# Altium schematic sheet Voiced Sample Box V9.00.schdoc
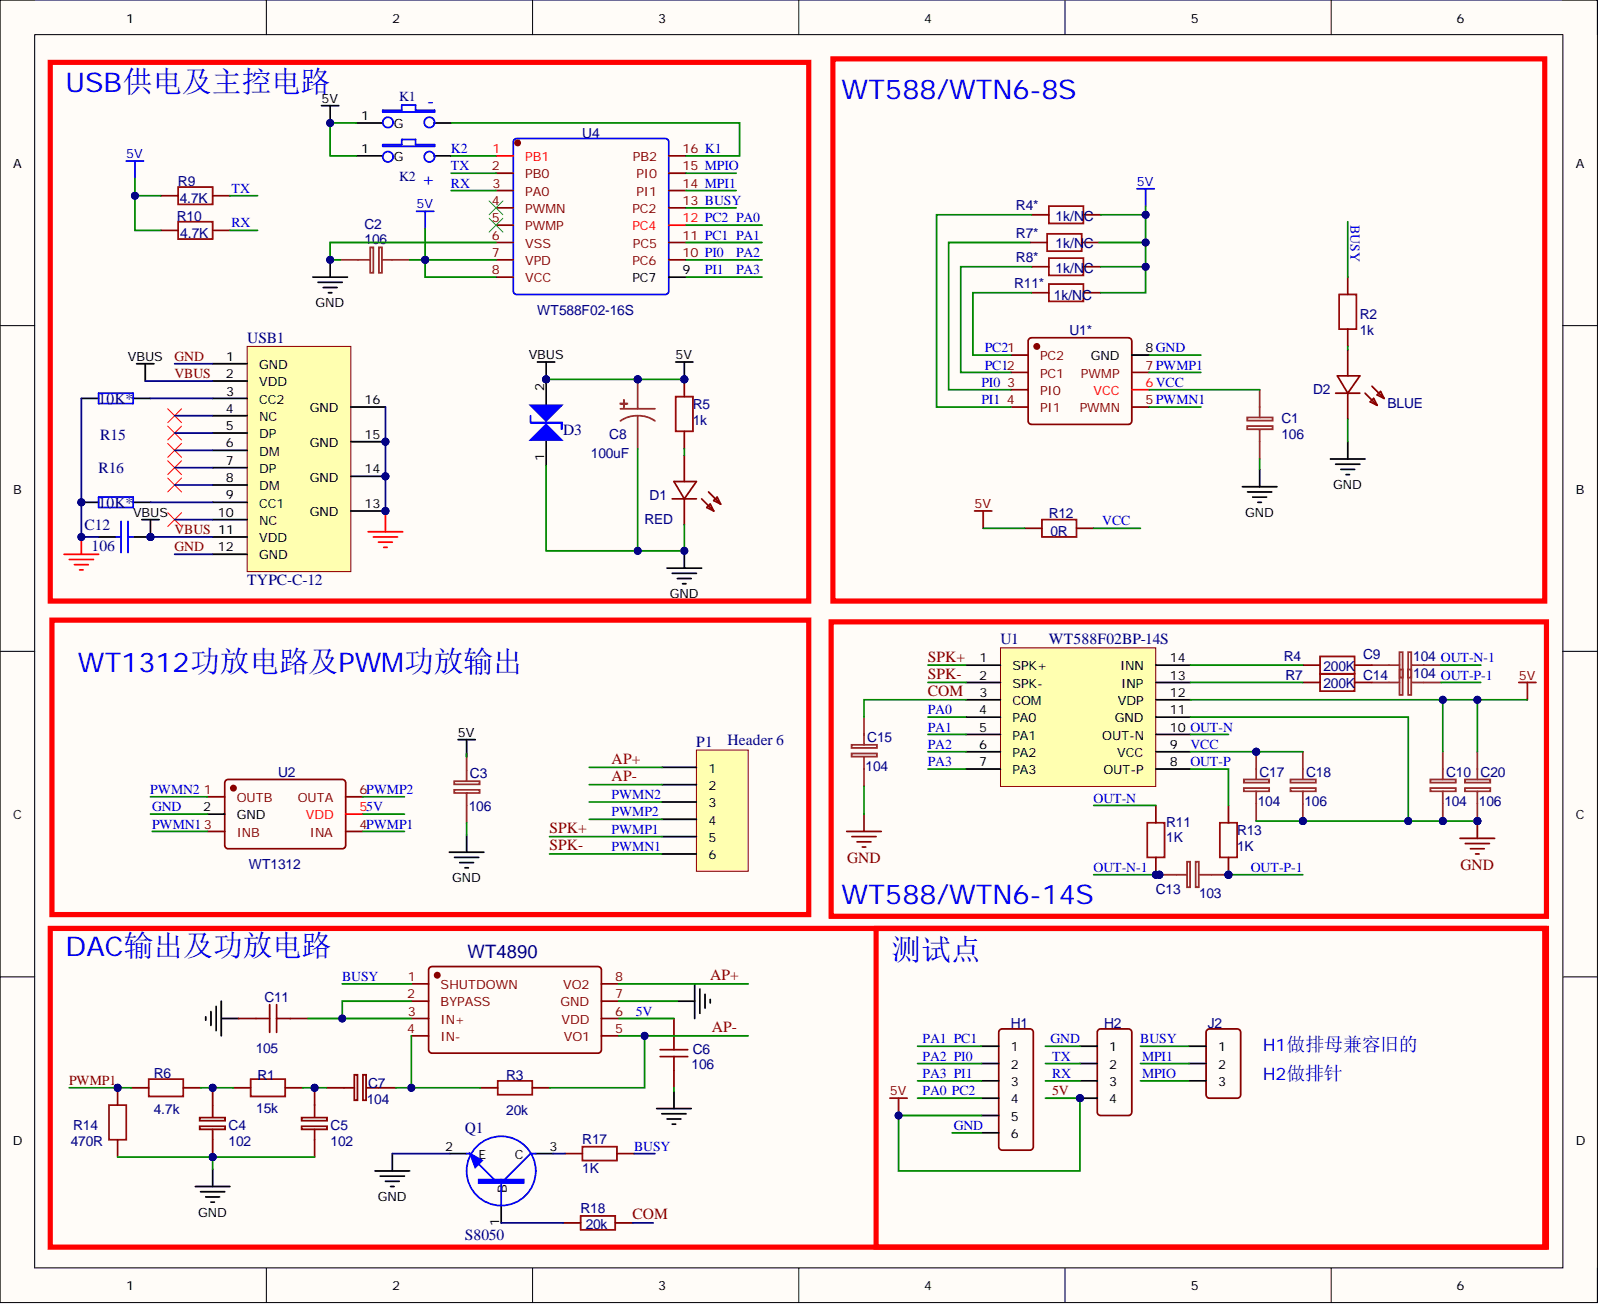
[diagram p0: Voiced Sample Box V9.00.schdoc]

## Parts
C1  106  [C-0603]
C2  106  [C-0603]
C3  106  [C-0603]
C4  102  [C-0603]
C5  102  [C-0603]
C6  106  [C-0603]
C7  104  [C-0603]
C8  100uF  [CAP-SMD-6.3*5.4]
C9  104  [C-0603]
C10  104  [C-0603]
C11  105  [C-0603]
C12  106  [C-0603]
C13  103  [C-0603]
C14  104  [C-0603]
C15  104  [C-0603]
C17  104  [C-0603]
C18  106  [C-0603]
C20  106  [C-0603]
D1  RED  [LED-0603]
D2  BLUE  [LED-0603]
D3  DIODE ZENER2  [r-0603]  (value not drawn)
H1  HDR-F-2.54_1x6  [SIP-6]  (value not drawn)
H2  HDR-F-2.54_1x4  [HDR-F-2.54_1X4]  (value not drawn)
J2  2.54_1x3  [sip-3]  (value not drawn)
K1  K1  [KEY-6*3*2.5]
K2  SW-PB  [KEY-6*3*2.5]  (value not drawn)
P1  Header 6  [HDR1X6]
Q1  S8050  [SOT-23]
R1  15k  [R-0603]
R2  1k  [R-0603]
R3  20k  [R-0603]
R4  200K  [R0603]
R4*  1k/NC  [R-0603]
R5  1k  [R-0603]
R6  4.7k  [R-0603]
R7  200K  [R0603]
R7*  1k/NC  [R-0603]
R8*  1k/NC  [R-0603]
R9  4.7K  [R-0603]
R10  4.7K  [R-0603]
R11  1K  [R0603]
R11*  1k/NC  [R-0603]
R12  0R  [R0603]
R13  1K  [R0603]
R14  470R  [R-0603]
R15  10K*  [R-0603]
R16  10K*  [R-0603]
R17  1K  [R-0603]
R18  20k  [R-0603]
U1  WT588F02BP-14S  [SOP-14-1.27-6]
U1*  WT588F-8S  [SOP-8]  (value not drawn)
U2  WT1312  [SOT23-6]
U4  WT588F02-16S  [SOP16_150MIL]
U8  WT4890  [MSOP-8]
USB1  TYPC-C-12  [TYPE-C-12-1]

## Nets
5V: C2.1 C3.1 C6.1 C8.1 C10.1 C12.1 C20.1 D3.2 H1.5 H2.4 K1.1 K2.1 R4*.1 R5.2 R7*.1 R8*.1 R9.2 R10.2 R11*.1 R12.2 U1.12(VDP) U2.5(VDD) U4.7(VPD) U4.8(VCC) U8.6(VDD) USB1.2(VDD) USB1.11(VDD)
AP+: P1.1 U8.8(VO2)
AP-: P1.2 R3.2 U8.5(VO1)
BUSY: J2.1 R2.2 R17.1 U4.13(PC2) U8.1(SHUTDOWN)
COM: C15.1 R18.2 U1.3(COM)
GND: C1.2 C2.2 C3.2 C4.1 C5.1 C6.2 C8.2 C10.2 C11.2 C12.2 C15.2 C17.2 C18.2 C20.2 D1.2 D2.2 D3.1 H1.6 H2.1 Q1.2 R14.1 R15.2 R16.2 U1.11(GND) U1*.8(GND) U2.2(GND) U4.6(VSS) U8.7(GND) USB1.1(GND) USB1.12(GND) USB1.13(GND) USB1.14(GND) USB1.15(GND) USB1.16(GND)
K1: K1.2 U4.16(PB2)
K2: K2.2 U4.1(PB1)
MPI1: J2.2 U4.14(PI1)
MPIO: J2.3 U4.15(PI0)
OUT-N: R11.1 U1.10(OUT-N)
OUT-N-1: C9.2 C13.1 R11.2
OUT-P: R13.1 U1.8(OUT-P)
OUT-P-1: C13.2 C14.2 R13.2
PA0: H1.4 R11*.2 U1.4(PA0) U1*.1(PC2) U4.12(PC4)
PA1: H1.1 R8*.2 U1.5(PA1) U1*.2(PC1) U4.11(PC5)
PA2: H1.2 R7*.2 U1.6(PA2) U1*.3(PI0) U4.10(PC6)
PA3: H1.3 R4*.2 U1.7(PA3) U1*.4(PI1) U4.9(PC7)
PWMN1: P1.6 U1.2(SPK-) U1*.5(PWMN) U2.3(INB)
PWMN2: P1.3 U2.1(OUTB)
PWMP1: P1.5 R6.1 R14.2 U1.1(SPK+) U1*.7(PWMP) U2.4(INA)
PWMP2: P1.4 U2.6(OUTA)
RX: H2.3 R10.1 U4.3(PA0)
TX: H2.2 R9.1 U4.2(PB0)
VCC: C1.1 C17.1 C18.1 R12.1 U1.9(VCC) U1*.6(VCC)
NetC4_2: C4.2 R1.1 R6.2
NetC5_2: C5.2 C7.1 R1.2
NetC7_2: C7.2 R3.1 U8.4(IN-)
NetC9_1: C9.1 R4.2
NetC11_1: C11.1 U8.2(BYPASS) U8.3(IN+)
NetC14_1: C14.1 R7.2
NetD1_1: D1.1 R5.1
NetD2_1: D2.1 R2.1
NetQ1_1: Q1.1 R18.1
NetQ1_3: Q1.3 R17.2
NetR4_1: R4.1 U1.14(INN)
NetR7_1: R7.1 U1.13(INP)
NetR15_1: R15.1 USB1.3(CC2)
NetR16_1: R16.1 USB1.9(CC1)

Not connected: U4 4(PWMN) 5(PWMP); USB1 4(NC) 5(DP) 6(DM) 7(DP) 8(DM) 10(NC)

## Library data of the parts
C1 C2 C9 C10 C13-C15 C17 C18 C20 = 106: 106 0603 10V 20%; Inventory Code: 01.002.001.0186; nameAlias: Value(F); Produck Name: 贴片普通电容; BOM_Manufacturer Part: ?; Component Kind: Standard; Footprint Path: .\Footprint_Lib_Custom\SMD贴片库.lib; Footprint Ref: C-0603; Library Path: .\Schematic Library\02 Capacitor.SCHLIB; Library Ref: CN-CAP; spicePre: C; spiceSymbolName: Cap_RAD-0.1_EU
C3 C7 = 104: 104 0603 50V X7R 三星牌子; Inventory Code: 01.002.001.0150; nameAlias: Value(F); Produck Name: 贴片普通电容; BOM_Manufacturer Part: ?; Component Kind: Standard; Footprint Path: .\Footprint_Lib_Custom\SMD贴片库.lib; Footprint Ref: C-0603; Library Path: .\Schematic Library\02 Capacitor.SCHLIB; Library Ref: CN-CAP; spicePre: C; spiceSymbolName: Cap_RAD-0.1_EU
C4 C5 = 102: 102 0603 50V 10% X7R; Inventory Code: 01.002.001.0004; nameAlias: Value(F); Produck Name: 贴片普通电容; BOM_Manufacturer Part: ?; Component Kind: Standard; Footprint Path: .\Footprint_Lib_Custom\SMD贴片库.lib; Footprint Ref: C-0603; Library Path: .\Schematic Library\02 Capacitor.SCHLIB; Library Ref: CN-CAP; spicePre: C; spiceSymbolName: Cap_RAD-0.1_EU
C6 C11 = 105: 105 0603 10V -20+80% Y5V; Inventory Code: 01.002.001.0007; nameAlias: Value(F); Produck Name: 贴片普通电容; BOM_Manufacturer Part: ?; Component Kind: Standard; Footprint Path: .\Footprint_Lib_Custom\SMD贴片库.lib; Footprint Ref: C-0603; Library Path: .\Schematic Library\02 Capacitor.SCHLIB; Library Ref: CN-CAP; spicePre: C; spiceSymbolName: Cap_RAD-0.1_US
C8 = 47uF/16V: BOM_Manufacturer: SUN Elec Industries; BOM_Manufacturer Part: 25CE22AX; Contributor: LCSC; nameAlias: Capacitance; BOM_Supplier: LCSC; BOM_Supplier Part: C242039; Component Kind: Standard; spicePre: C; spiceSymbolName: 25CE22AX copy
C12 = CAP: Capacitor; Component Kind: Standard
D1 = RED: 红灯 0603; BOM_Manufacturer: EVERLIGHT; BOM_Manufacturer Part: 204-10SURD/S530-A3; Inventory Code: 01.014.0008; Produck Name: 贴片LED红灯; BOM_Supplier: LCSC; BOM_Supplier Part: C99772; Component Kind: Standard; Footprint Path: .\Footprint_Lib_Custom\二三极管.PcbLib; Footprint Ref: LED-0603; Library Ref: CN-LED; spicePre: L; Library Path: .\Schematic_Lib_Custom\二三极管.SchLib
D2 = BLUE: BOM_Manufacturer: EVERLIGHT; BOM_Manufacturer Part: 204-10SURD/S530-A3; BOM_Supplier: LCSC; BOM_Supplier Part: C99772; Component Kind: Standard; spicePre: L
D3 = DIODE ZENER2: 双向稳压二极管 TVS管; Component Kind: Standard; Library Name: Diode.SchLib
H1 = HDR-F-2.54_1x6: Contributor: LCEDA_Lib; BOM_Supplier: LCSC; BOM_Supplier Part: C40877; Component Kind: Standard; spicePre: H; spiceSymbolName: HDR-F-2.54_1x6
H2 = HDR-F-2.54_1x4: Contributor: LCEDA_Lib; BOM_Supplier: LCSC; BOM_Supplier Part: C225501; Component Kind: Standard; spicePre: H; spiceSymbolName: HDR-F-2.54_1x4
J2 = 2.54_1x3: BOM_Manufacturer: BOOMELE; BOM_Manufacturer Part: Header2.54mm 1*3P; BOM_JLCPCB Part Class: Extended Part; BOM_Supplier: LCSC; BOM_Supplier Part: C49257; Component Kind: Standard; spicePre: H; spiceSymbolName: Header-Male-2.54_1x3
P1 = Header 6: Header, 6-Pin; Component Kind: Standard; LatestRevisionDate: 17-Jul-2002; LatestRevisionNote: Re-released for DXP Platform.; Library Name: Miscellaneous Connectors.IntLib; Signal Integrity: Connector; Publisher: Altium Limited
Q1 = NPN-1: NPN Transistor; Component Kind: Standard; Library Name: Diode.SchLib
R1 = 15k: 15K 0603 1%; Contributor: LCEDA_Lib; Inventory Code: 01.001.001.0022; nameAlias: Value(Ω); Produck Name: 贴片电阻; Component Kind: Standard; Footprint Path: .\Footprint_Lib_Custom\SMD贴片库.lib; Footprint Ref: R-0603; Library Path: .\Schematic Library\01 Resistor.SCHLIB; Library Ref: CN-RES2; spicePre: R; spiceSymbolName: R_0603_EU
R2 R5 = 1k: 1K 0603 1%; Inventory Code: 01.001.001.0335; nameAlias: Value(Ω); Produck Name: 贴片电阻; BOM_Manufacturer Part: ?; Component Kind: Standard; Footprint Path: .\Footprint_Lib_Custom\SMD贴片库.lib; Footprint Ref: R-0603; Library Path: .\Schematic Library\01 Resistor.SCHLIB; Library Ref: CN-RES2; spicePre: R; spiceSymbolName: Res_0603(1/10W)_EU
R3 R17 R18 = 20k: 20K 0603 1%; Inventory Code: 01.001.001.0346; nameAlias: Value(Ω); Produck Name: 贴片电阻; BOM_Manufacturer Part: ?; Component Kind: Standard; Footprint Path: .\Footprint_Lib_Custom\SMD贴片库.lib; Footprint Ref: R-0603; Library Path: .\Schematic Library\01 Resistor.SCHLIB; Library Ref: CN-RES2; spicePre: R; spiceSymbolName: Res_AXIAL-0.3(1/8W)_EU
R4 R7 R11-R13 = 0R: nameAlias: Value(Ω); BOM_Manufacturer Part: ?; Component Kind: Standard; spicePre: R; spiceSymbolName: Res_0603(1/10W)_EU
R4* R7* R8* R11* = 1k: nameAlias: Value(Ω); BOM_Manufacturer Part: ?; Component Kind: Standard; spicePre: R; spiceSymbolName: Res_0603(1/10W)_EU
R6 = 4.7k: 4.7K 0603 5%; Contributor: LCEDA_Lib; Inventory Code: 01.001.001.0068; nameAlias: Value(Ω); Produck Name: 贴片电阻; Component Kind: Standard; Footprint Path: .\Footprint_Lib_Custom\SMD贴片库.lib; Footprint Ref: R-0603; Library Path: .\Schematic Library\01 Resistor.SCHLIB; Library Ref: CN-RES2; spicePre: R; spiceSymbolName: R_0603_EU
R9 R10 = 4.7K: 4.7K 0603 5%; Inventory Code: 01.001.001.0068; nameAlias: Value(Ω); Produck Name: 贴片电阻; BOM_Manufacturer Part: ?; Component Kind: Standard; Footprint Path: .\Footprint_Lib_Custom\SMD贴片库.lib; Footprint Ref: R-0603; Library Path: .\Schematic Library\01 Resistor.SCHLIB; Library Ref: CN-RES2; spicePre: R; spiceSymbolName: Res_0603(1/10W)_EU
R14 = 470R: 470R 0603 5%; Contributor: LCEDA_Lib; Inventory Code: 01.001.001.0074; nameAlias: Value(Ω); Produck Name: 贴片电阻; Component Kind: Standard; Footprint Path: .\Footprint_Lib_Custom\SMD贴片库.lib; Footprint Ref: R-0603; Library Path: .\Schematic Library\01 Resistor.SCHLIB; Library Ref: CN-RES2; spicePre: R; spiceSymbolName: R_0603_EU
R15 R16 = RES: Component Kind: Standard
U1 = WT588F02BP-14S: WT588F02BP-14S内置3W差分功放; Component Kind: Standard; Library Name: WT_IC.SchLib
U1* = WT588F-8S: Contributor: yinzhengfeng; BOM_Manufacturer Part: ?; Component Kind: Standard; spicePre: U
U2 = WT1312: SOT236C; Contributor: yinzhengfeng; Inventory Code: 00.003.008.001.0078; BOM_Manufacturer Part: ?; Component Kind: Standard; Footprint Path: .\Footprint_Lib_Custom\芯片.PcbLib; Footprint Ref: SOT23-6; Library Path: .\Schematic_Lib_Custom\芯片.SchLib; Library Ref: CN-WT1312; Produck Name: WT1312; spicePre: U
U4 = WT588F02-16S: Contributor: yinzhengfeng; Package Display: No; BOM_Manufacturer Part: ?; Component Kind: Standard; spicePre: U
U8 = WT4890: BOM_Manufacturer: SHOUDING; BOM_Manufacturer Part: LM4890S; Contributor: yinzhengfeng; BOM_Supplier: LCSC; BOM_Supplier Part: C171624; Component Kind: Standard; spicePre: U
USB1 = TYPE-C-12_1: Component Kind: Standard; Description: TYPC-C-12; Library Name: Other.SchLib
K1 = K1: Component Kind: Standard
K2 = SW-PB: Component Kind: Standard
